# Altium schematic sheet delta-sigma_modulator.SchDoc(delta-sigma_modulator)
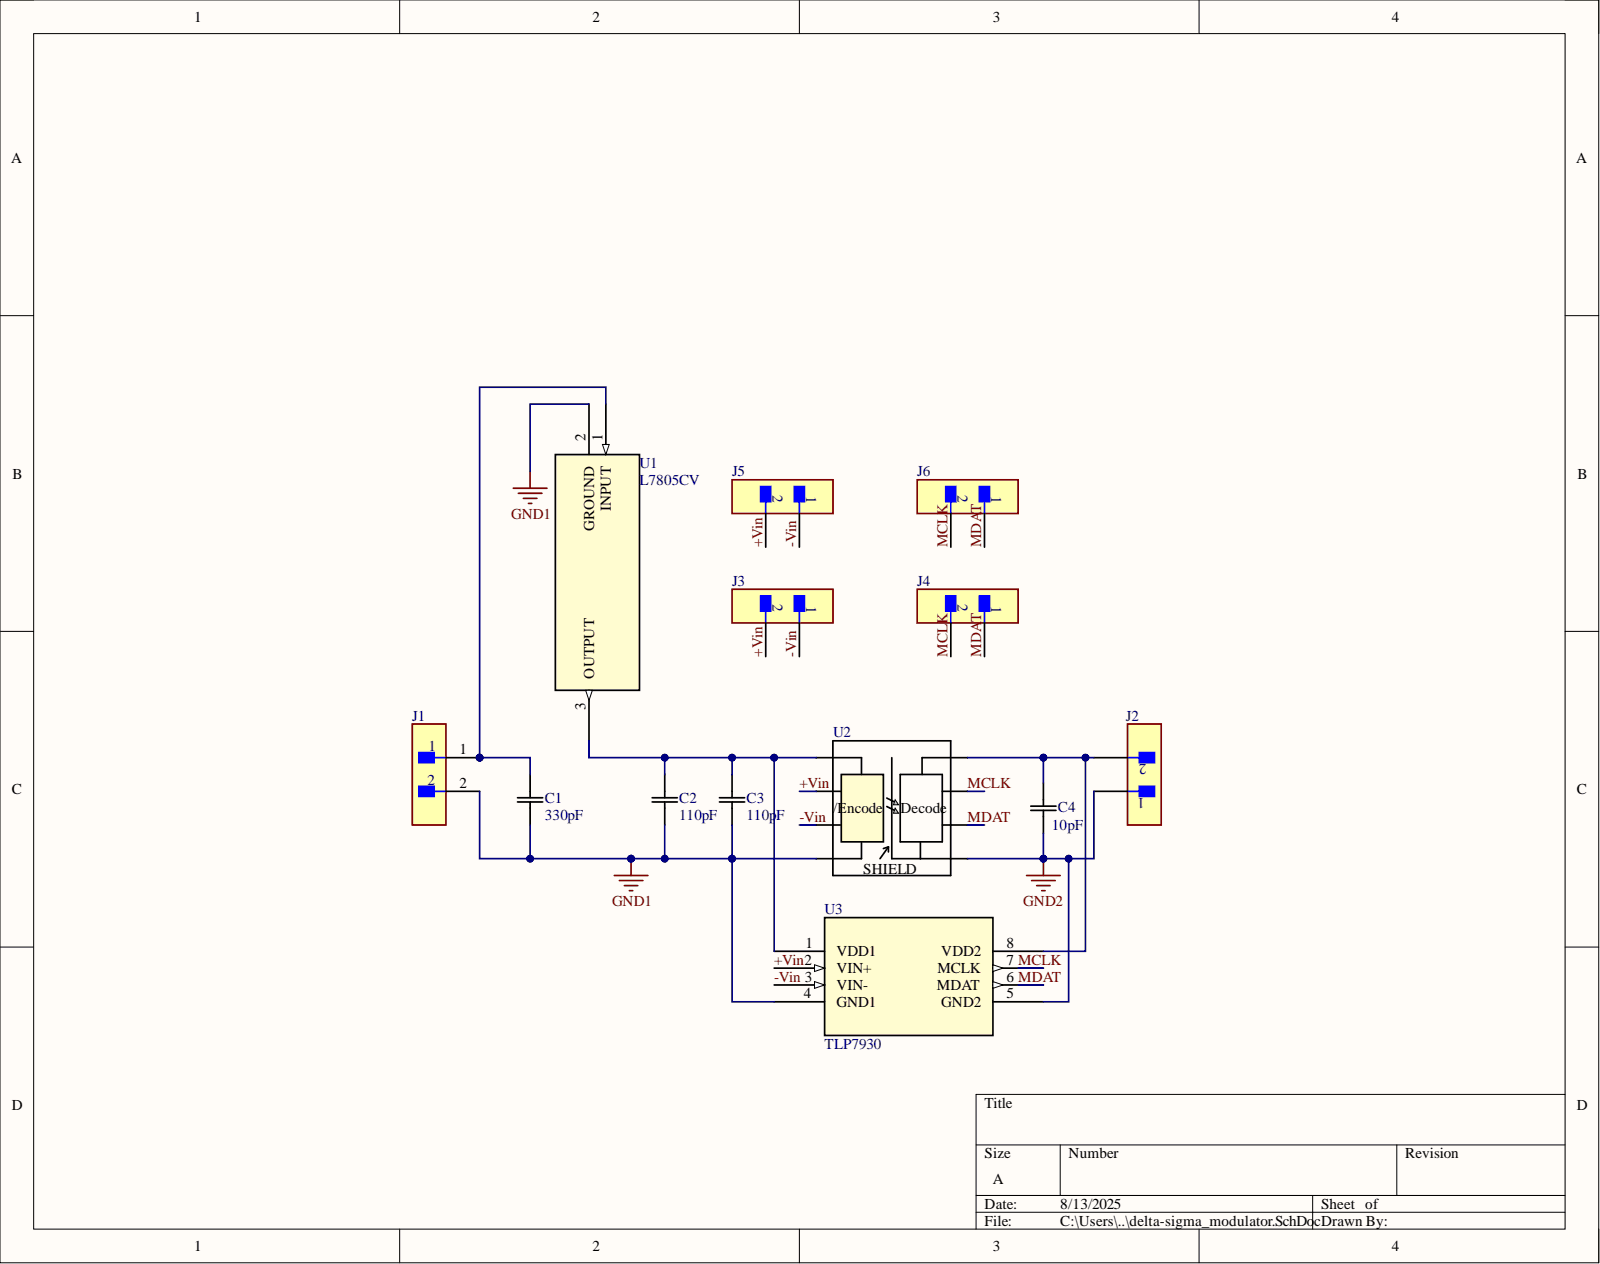
[diagram p0: delta-sigma_modulator.SchDoc]

## Parts
C1  330pF  [CAP_FG28_TDK]
C2  110pF  [CAP_FG28_TDK]
C3  110pF  [CAP_FG28_TDK]
C4  10pF  [CAP_C322C511_MR_KEM]
J1  M20-9990246  [FP-M20-9990246-MFG]  (value not drawn)
J2  M20-9990246  [FP-M20-9990246-MFG]  (value not drawn)
J3  M20-9990246  [FP-M20-9990246-MFG]  (value not drawn)
J4  M20-9990246  [FP-M20-9990246-MFG]  (value not drawn)
J5  M20-9990246  [FP-M20-9990246-MFG]  (value not drawn)
J6  M20-9990246  [FP-M20-9990246-MFG]  (value not drawn)
U1  L7805CV  [TO-220_STM]
U2  TLP7830TP4,E  [SO8L_TOS]  (value not drawn)
U3  TLP7930  [DIP8_TOS]

## Nets
GND1: C1.1 C2.1 C3.1 J1.2 U1.2 U2.4 U3.4(GND1)
GND2: C4.1 J2.2 U2.5 U3.5(GND2)
+Vin: J3.2 J5.2 U2.2 U3.2(VIN+)
-Vin: J3.1 J5.1 U2.3 U3.3(VIN-)
MCLK: J4.2 J6.2 U2.7 U3.7(MCLK)
MDAT: J4.1 J6.1 U2.6 U3.6(MDAT)
NetC1_2: C1.2 J1.1 U1.1
NetC2_2: C2.2 C3.2 U1.3 U2.1 U3.1(VDD1)
NetC4_2: C4.2 J2.1 U2.8 U3.8(VDD2)

## Library data of the parts
C1 = FG28C0G1H331JNT06: No Description Available; Mfr_Name: TDK; Component Kind: Standard; Library Name: Schlib1.SchLib
C2 C3 = FG28C0G1H100DNT06: No Description Available; Mfr_Name: TDK; Component Kind: Standard; Library Name: Schlib1.SchLib
C4 = C322C111JDG5TA: No Description Available; Mfr_Name: Kemet; Component Kind: Standard; Library Name: Schlib1.SchLib
J1-J6 = CMP-15831-000009-1: CONN HEADER VERT 2POS 2.54MM; Manufacturer: Harwin; Conn Gender: Male; Conn Orientation: Vertical; Current Rating: 3A; Number Of Contacts: 2; Automotive: No; Category: Conn; Component Kind: Standard; ComponentLink2Description: Datasheet; ComponentLink2URL: https://cdn.harwin.com/pdfs/M20-999.pdf; Contact Material: Tin,Nickel; Device Class L1: Connectors; Device Class L2: Headers and Wire Housings; Device Class L3: unset; Digikey Description: CONN HEADER VERT 2POS 2.54MM; Height: 8.99mm; Lead Free: Yes; Library Name: Altium Content Vault; Manufacturer Part Number: M20-9990246; Mouser Description: 2.54mm (0.1") Pitch SIL Vertical PC Tail Pin Header, 6.1mm mating pin height, tin, 2 contacts; Number Of Rows: 1; Octopart: https://octopart.com/m20-9990246-harwin-2590635; Package: M20-9990246; Pitch: 2.54mm; RoHS: TRUE; Temperature: -40°C to +105°C; Temperature Range High: +105°C; Temperature Range Low: -40°C
U1 = L7805CV: Linear Voltage Regulator, Fixed, Positive Output, 35 V, 5 V, 1.5 A, 3 Pins, TO-220; Manufacturer: STMicroelectronics; Dropout Voltage: 2V; Max Input Voltage: 35V; Max Output Current: 1.5A; Min Input Voltage: 7V; Min Output Voltage: 4.65V; Nominal Output Voltage: 5V; Number of Channels: 1; Power Supply Rejection Ratio (PSRR): 62dB; Quiescent Current: 8mA; Tolerance: 5%; Case/Package: TO-220; Category: Voltage Regulators - Linear; Component Kind: Standard; ComponentLink1Description: https://datasheet.ciiva.com/29156/156048-da-01-en-spannungsregler-l-7805-cv-to220-stm-29156924.pdf?src-supplier=Conrad; ComponentLink1URL: https://datasheet.ciiva.com/29156/156048-da-01-en-spannungsregler-l-7805-cv-to220-stm-29156924.pdf?src-supplier=Conrad; ComponentLink2Description: https://datasheet.ciiva.com/6884/1805459-6884463.pdf?src-supplier=Newark; ComponentLink2URL: https://datasheet.ciiva.com/6884/1805459-6884463.pdf?src-supplier=Newark; ComponentLink3Description: https://datasheet.ciiva.com/2073/cd00000444-2073087.pdf?src-supplier=Digikey; ComponentLink3URL: https://datasheet.ciiva.com/2073/cd00000444-2073087.pdf?src-supplier=Digikey; ComponentLink4Description: https://datasheet.ciiva.com/16458/cd00000444-16458159.pdf?src-supplier=Future+Electronics; ComponentLink4URL: https://datasheet.ciiva.com/16458/cd00000444-16458159.pdf?src-supplier=Future+Electronics; Contact Plating: Gold; Height: 9.15mm; Lead Free: Lead Free; Length: 10.4mm; Library Name: Schlib1.SchLib; Manufacturer Part Number: L7805CV; Max Operating Temperature: 125°C; Mfr_Name: STMicroelectronics; Min Operating Temperature: -40°C; Mount: Through Hole; Number of Outputs: 1; Number of Pins: 3; Number of Regulators: 1; Output Current: 1.5A; Output Type: Fixed; Output Voltage: 5V; Polarity: Positive; Pricing 1: 1=0.53, 10=0.375, 50=0.3118, 100=0.2922, 250=0.27136, 500=0.2588, 1000=0.24847, 2500=0.23757, 5000=0.23099 (USD); Radiation Hardening: No; Reach SVHC Compliant: No; RefDes: RefDes; RoHS: No; Stock 1: 39349; Supplier 1: Digikey; Supplier Part Number 1: 497-1443-5-ND; Type: Type; Weight: 2.299997g; Width: 4.6mm
U2 = TLP7830TP4,E: Isolation Amplifier Single 5000Vrms 5V 8-Pin SOIC T/R; Manufacturer: Toshiba; Manufacturer Part Number: TLP7830(TP4,E; Mfr_Name: Toshiba Electronic Devices & Storage Corp.; Number_devices_in_Package: 1; Category: Linear ICs; Component Kind: Standard; Library Name: Schlib1.SchLib; Pricing 1: 1=6.34, 10=4.768, 100=3.8437, 500=3.519 (USD); RefDes: Refdes; RoHS: Yes; RoHS Compliant: Yes; Source_Package: TLP7830; Stock 1: 1205; Supplier 1: Digikey; Supplier Part Number 1: TLP7830(TP4ECT-ND; Type: Type
U3 = TLP7930: No Description Available; Mfr_Name: Toshiba Electronic Devices & Storage Corp.; Component Kind: Standard; Library Name: Schlib1.SchLib; RefDes: RefDes; Type: Type
